# Altium schematic sheet 04_BK0&FLASH.SchDoc(04_BK0&FLASH)
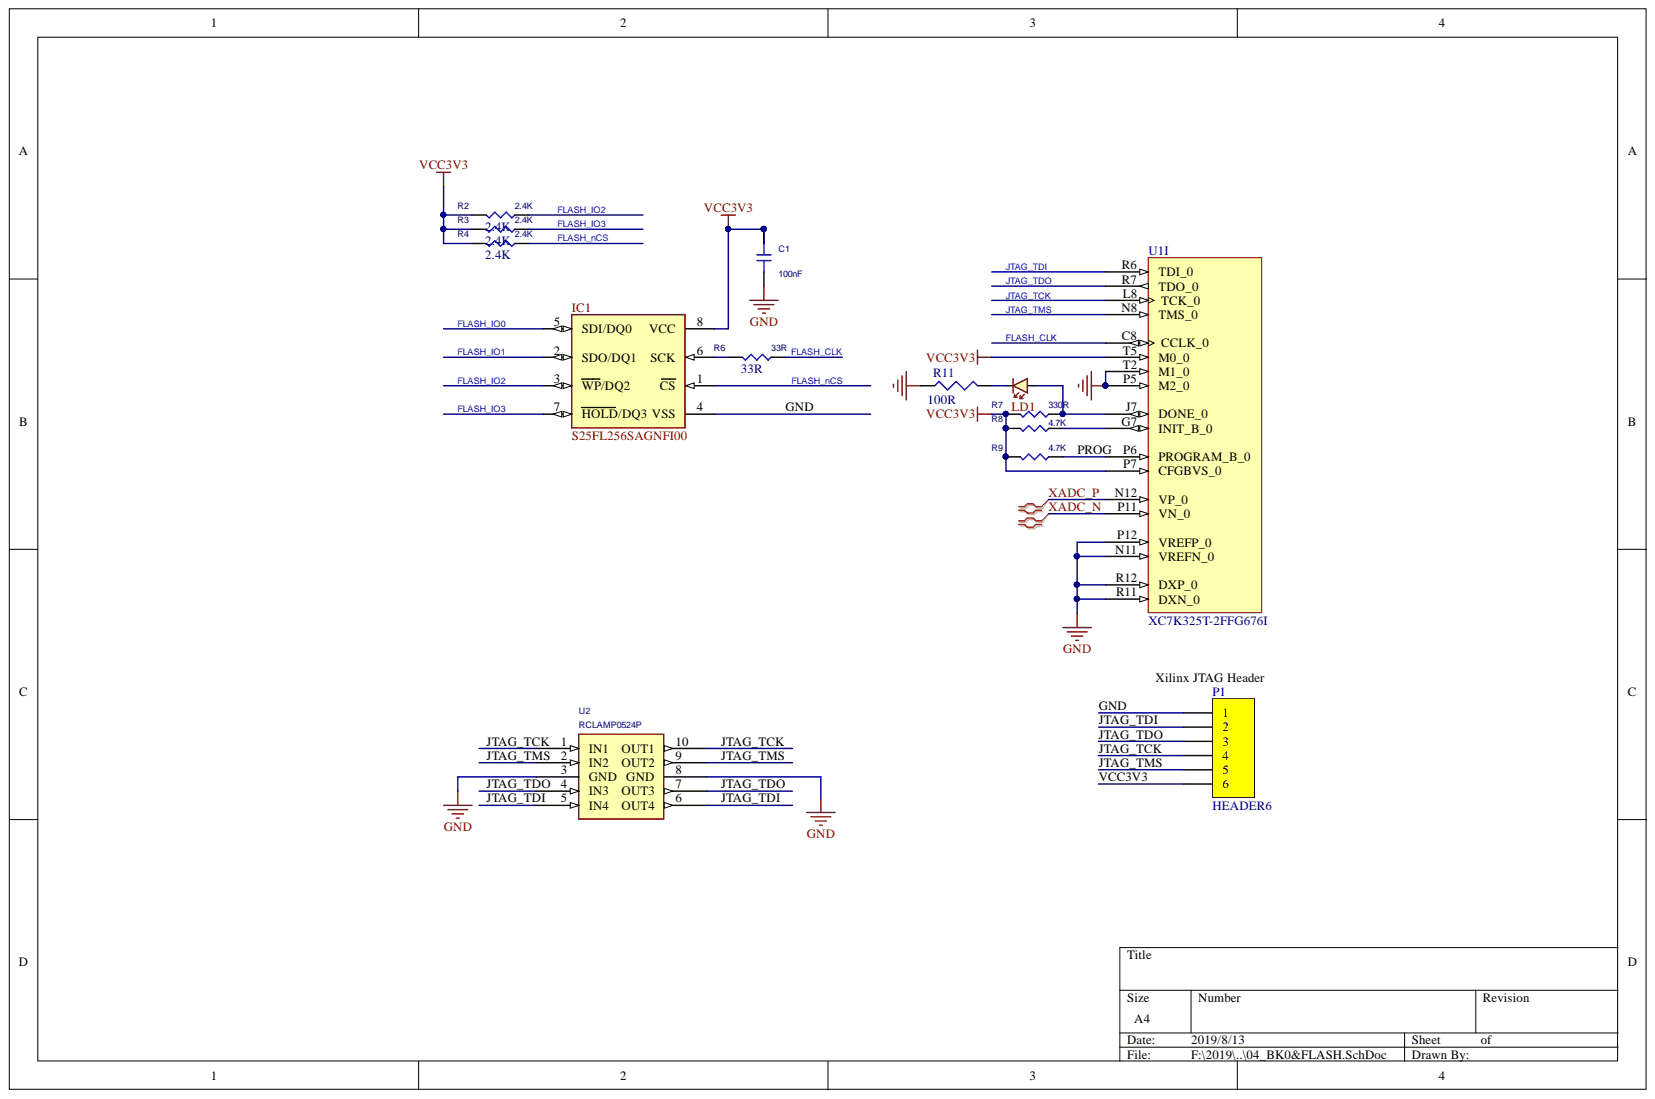
[diagram p0: 04_BK0&FLASH.SchDoc]

## Parts
C1  100nF  [C0402]
IC1  S25FL256SAGNFI00  [SOIC8N]
LD1  LED  [D0603]  (value not drawn)
P1  HEADER6  [HEADER1X6]
R2  2.4K  [RES0402]
R3  2.4K  [RES0402]
R4  2.4K  [RES0402]
R6  33R  [R0402]
R7  330R  [R0402]
R8  4.7K  [R0402]
R9  4.7K  [R0402]
R11  100R  [res0402]
U1  XC7K325T-2FFG676I  [FFG676]  (part I)
U2  RCLAMP0524P  [SLP2510P8_10]

## Nets
FLASH_CLK: R6.2 U1.C8(CCLK_0)
FLASH_IO0: IC1.5(SDI/DQ0)
FLASH_IO1: IC1.2(SDO/DQ1)
FLASH_IO2: IC1.3(WP/DQ2) R2.2
FLASH_IO3: IC1.7(HOLD/DQ3) R3.2
FLASH_NCS: IC1.1(CS) R4.2
GND: C1.1 IC1.4(VSS) P1.1 R11.1 U1.N11(VREFN_0) U1.P5(M2_0) U1.P12(VREFP_0) U1.R11(DXN_0) U1.R12(DXP_0) U1.T2(M1_0) U2.3(GND) U2.8(GND)
JTAG_TCK: P1.4 U1.L8(TCK_0) U2.1(IN1) U2.10(OUT1)
JTAG_TDI: P1.2 U1.R6(TDI_0) U2.5(IN4) U2.6(OUT4)
JTAG_TDO: P1.3 U1.R7(TDO_0) U2.4(IN3) U2.7(OUT3)
JTAG_TMS: P1.5 U1.N8(TMS_0) U2.2(IN2) U2.9(OUT2)
PROG: R9.2 U1.P6(PROGRAM_B_0)
VCC3V3: C1.2 IC1.8(VCC) P1.6 R2.1 R3.1 R4.1 R7.1 R8.1 R9.1 U1.P7(CFGBVS_0) U1.T5(M0_0)
XADC_N: U1.P11(VN_0)
XADC_P: U1.N12(VP_0)
NetIC1_6: IC1.6(SCK) R6.1
NetLD1_A: LD1.A R7.2 U1.J7(DONE_0)
NetLD1_K: LD1.K R11.2
NetR8_2: R8.2 U1.G7(INIT_B_0)

## Library data of the parts
C1 = CAP1_Dup1: CURRENT: CIMAX; DIST: FLAT; KNEE: CBMAX; MAX_TEMP: CTMAX; PSpiceTemplate: C^@REFDES %1 %2 ?TOLERANCE|C^@REFDES| @VALUE ?IC/IC=@IC/ TC=@TC1,@TC2 ?TOLERANCE|\n.model C^@REFDES CAP C=1 DEV=@TOLERANCE% TC1=@TC1 TC2=@TC2 VC1=@VC1 VC2=@VC2|; REUSE_ANNOTATE: TRUE; SLOPE: CSMAX; TC1: 0; VOLTAGE: CMAX; Component Kind: Standard; Simulation: CAP1; TC2: 0; Value: 100nF; VC1: 0; VC2: 0
IC1 = N25Q12-F8: Serial Flash Memory, 16Mbit, Low Voltage, 50MHz SPI Bus Interface; Manufacturer: Spansion; Value: No Load; Component Kind: Standard; Library Name: Digilent.IntLib
LD1 = LED: Generic LED; Value: GRN; Component Kind: Standard; Library Name: milinker.IntLib
R2 = RES1: DIST: FLAT; MAX_TEMP: RTMAX; POWER: RMAX; PSpiceTemplate: R^@REFDES %1 %2 ?TOLERANCE|R^@REFDES| @VALUE TC=@TC1,@TC2 ?TOLERANCE|\n.model R^@REFDES RES R=1 DEV=@TOLERANCE% TC1=@TC1 TC2=@TC2|; REUSE_ANNOTATE: TRUE; REUSE_ID: 43; SLOPE: RSMAX; TC1: 0; VOLTAGE: RVMAX; Component Kind: Standard; Simulation: RES1; TC2: 0; Value: 2.4K
R3 = RES1: DIST: FLAT; MAX_TEMP: RTMAX; POWER: RMAX; PSpiceTemplate: R^@REFDES %1 %2 ?TOLERANCE|R^@REFDES| @VALUE TC=@TC1,@TC2 ?TOLERANCE|\n.model R^@REFDES RES R=1 DEV=@TOLERANCE% TC1=@TC1 TC2=@TC2|; REUSE_ANNOTATE: TRUE; REUSE_ID: 33; SLOPE: RSMAX; TC1: 0; VOLTAGE: RVMAX; Component Kind: Standard; Simulation: RES1; TC2: 0; Value: 2.4K
R4 = RES1: DIST: FLAT; MAX_TEMP: RTMAX; POWER: RMAX; PSpiceTemplate: R^@REFDES %1 %2 ?TOLERANCE|R^@REFDES| @VALUE TC=@TC1,@TC2 ?TOLERANCE|\n.model R^@REFDES RES R=1 DEV=@TOLERANCE% TC1=@TC1 TC2=@TC2|; REUSE_ANNOTATE: TRUE; REUSE_ID: 40; SLOPE: RSMAX; TC1: 0; VOLTAGE: RVMAX; Component Kind: Standard; Simulation: RES1; TC2: 0; Value: 2.4K
R6 = RES1: DIST: FLAT; MAX_TEMP: RTMAX; POWER: RMAX; PSpiceTemplate: R^@REFDES %1 %2 ?TOLERANCE|R^@REFDES| @VALUE TC=@TC1,@TC2 ?TOLERANCE|\n.model R^@REFDES RES R=1 DEV=@TOLERANCE% TC1=@TC1 TC2=@TC2|; REUSE_ANNOTATE: TRUE; SLOPE: RSMAX; TC1: 0; VOLTAGE: RVMAX; Component Kind: Standard; Simulation: RES1; TC2: 0; Value: 33R
R7 = RES1: DIST: FLAT; MAX_TEMP: RTMAX; POWER: RMAX; PSpiceTemplate: R^@REFDES %1 %2 ?TOLERANCE|R^@REFDES| @VALUE TC=@TC1,@TC2 ?TOLERANCE|\n.model R^@REFDES RES R=1 DEV=@TOLERANCE% TC1=@TC1 TC2=@TC2|; REUSE_ANNOTATE: TRUE; REUSE_ID: 45; SLOPE: RSMAX; TC1: 0; VOLTAGE: RVMAX; Component Kind: Standard; Simulation: RES1; TC2: 0; Value: 330R
R8 = RES1: DIST: FLAT; MAX_TEMP: RTMAX; POWER: RMAX; PSpiceTemplate: R^@REFDES %1 %2 ?TOLERANCE|R^@REFDES| @VALUE TC=@TC1,@TC2 ?TOLERANCE|\n.model R^@REFDES RES R=1 DEV=@TOLERANCE% TC1=@TC1 TC2=@TC2|; REUSE_ANNOTATE: TRUE; REUSE_ID: 30; SLOPE: RSMAX; TC1: 0; VOLTAGE: RVMAX; Component Kind: Standard; Simulation: RES1; TC2: 0; Value: 4.7K
R9 = RES1: DIST: FLAT; MAX_TEMP: RTMAX; POWER: RMAX; PSpiceTemplate: R^@REFDES %1 %2 ?TOLERANCE|R^@REFDES| @VALUE TC=@TC1,@TC2 ?TOLERANCE|\n.model R^@REFDES RES R=1 DEV=@TOLERANCE% TC1=@TC1 TC2=@TC2|; REUSE_ANNOTATE: TRUE; REUSE_ID: 55; SLOPE: RSMAX; TC1: 0; VOLTAGE: RVMAX; Component Kind: Standard; Simulation: RES1; TC2: 0; Value: 4.7K
R11 = Res3: Resistor; Component Kind: Standard; LatestRevisionDate: 29-May-2009; LatestRevisionNote: IPC-7351 Footprint Added.; Library Name: MK7X.IntLib; PackageDescription: Chip Resistor; PackageReference: J1-0603; Published: 8-Jun-2000; Signal Integrity: Res; Simulation: RESISTOR; Value: 100R; Publisher: Altium Limited
U1 = XC7K325T-2FFG676I: Kintex-7 FPGA, 500 User I/Os, 8 GTX, 676-Ball BGA, Speed Grade 2, Industrial Grade, Pb-Free; Manufacturer: Xilinx; GTX: 8; SelectIO HP: 150; SelectIO HR: 250; Speed Grade: -2; Code_JEDEC: MS-034-AAL-1; Component Kind: Standard; ComponentLink1Description: Datasheet; ComponentLink1URL: http://www.xilinx.com/support/documentation/data_sheets/ds180_7Series_Overview.pdf; ComponentLink2Description: Package Specification; ComponentLink2URL: http://www.xilinx.com/support/documentation/user_guides/ug865-Zynq-7000-Pkg-Pinout.pdf; DatasheetVersion: v1.12; Generic Part Number: XC7K325T; Library Name: Xilinx Kintex-7.SchLib; Mounting Technology: Surface Mount; PackageDescription: Flip-Chip BGA, Body 27 x 27 mm, 1.0 mm Pitch; PackageReference: FFG676; PackageVersion: Rev 1.1; PartNumber: XC7K325T-2FFG676I; RoHS: Pb-Free
P1 = HEADER6: Component Kind: Standard; Library Name: jinrylib.IntLib
U2 = RCLAMP0524P: Component Kind: Standard
